# Altium schematic sheet Main Board.SchDoc
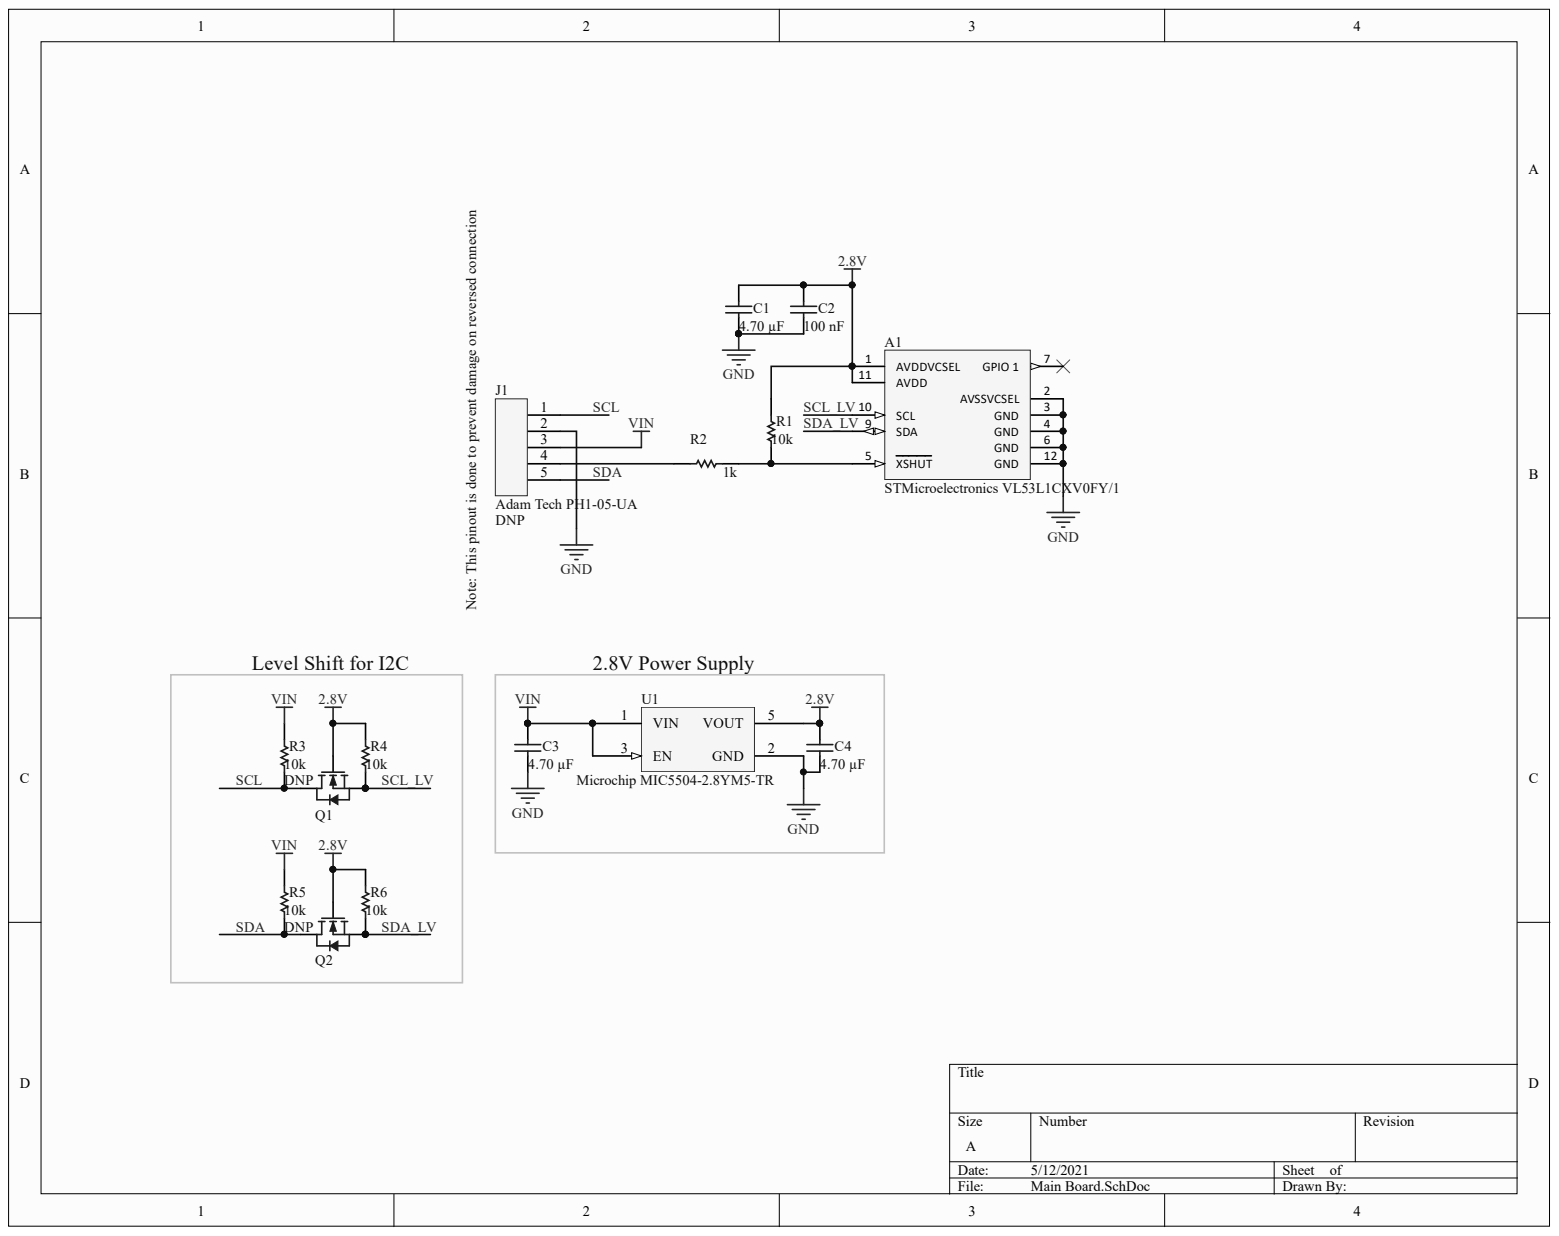
[diagram p0: Main Board.SchDoc]

## Parts
A1  STMicroelectronics VL53L1CXV0FY/1  Manufacturer Part Number: VL53L1CXV0FY/1  [VL53L1C]
C1  Capacitance: 4.70 µF  [PCB-4kws2ejwr5jtmehi4fny-1]  (hidden comment: Samsung CL10A475MQ8NNNC)
C2  Capacitance: 100 nF  [CAPC1005X56X25NL10T15]  (hidden comment: Samsung CL05A104KA5NNNC)
C3  Capacitance: 4.70 µF  [PCB-4kws2ejwr5jtmehi4fny-1]  (hidden comment: Samsung CL10A475MQ8NNNC)
C4  Capacitance: 4.70 µF  [PCB-4kws2ejwr5jtmehi4fny-1]  (hidden comment: Samsung CL10A475MQ8NNNC)
J1  Adam Tech PH1-05-UA  Manufacturer Part Number: PH1-05-UA  [PCB-wzxd1d1storh51uhdjer-1]
Q1  Nexperia 2N7002NXAKR  [PCB-lygu1wj07d1mwbs3aw73-1]  (value not drawn)
Q2  Nexperia 2N7002NXAKR  [PCB-lygu1wj07d1mwbs3aw73-1]  (value not drawn)
R1  Resistance: 10k  [RMCF0402]  (hidden comment: Stackpole Electronics RMCF0402JT10K0)
R2  Resistance: 1k  [PCB-xfncg8r2z74zylg35qj5-1]  (hidden comment: Stackpole Electronics RMCF0402JT1K00)
R3  Resistance: 10k  [RMCF0402]  (hidden comment: Stackpole Electronics RMCF0402JT10K0)
R4  Resistance: 10k  [RMCF0402]  (hidden comment: Stackpole Electronics RMCF0402JT10K0)
R5  Resistance: 10k  [RMCF0402]  (hidden comment: Stackpole Electronics RMCF0402JT10K0)
R6  Resistance: 10k  [RMCF0402]  (hidden comment: Stackpole Electronics RMCF0402JT10K0)
U1  Microchip MIC5504-2.8YM5-TR  Manufacturer Part Number: MIC5504-2.8YM5-TR  [PCB-87v9kgqs8q23ekp4z6f0-1]

## Nets
2.8V: A1.1(AVDDVCSEL) A1.11(AVDD) C1.2 C2.2 C4.2 Q1.1 Q2.1 R1.2 R4.2 R6.2 U1.5(VOUT)
GND: A1.2(AVSSVCSEL) A1.3(GND) A1.4(GND) A1.6(GND) A1.12(GND) C1.1 C2.1 C3.1 C4.1 J1.2 U1.2(GND)
VIN: C3.2 J1.3 R3.2 R5.2 U1.1(VIN) U1.3(EN)
SCL: J1.1 Q1.3 R3.1
SCL_LV: A1.10(SCL) Q1.2 R4.1
SDA: J1.5 Q2.3 R5.1
SDA_LV: A1.9(SDA) Q2.2 R6.1
NetA1_5: A1.5(XSHUT) R1.1 R2.2
NetJ1_4: J1.4 R2.1

Not connected: A1 7(GPIO_1)

## Library data of the parts
A1 = CMP-646fa835e915bba8-12: Proximity Sensor Environmental 4mm 2.6V to 3.5VDC 12-Pin LGA T/R; Lead-Free Status: Lead Free; Supply Voltage (DC): 2.60 V (min), 3.50 V (max); Component Kind: Standard; Library Name: CommunityVault; Lifecycle Status: Not Listed by Manufacturer; OctopartID: 646fa835e915bba8; Octopart URL: https://octopart.com/vl53l1cxv0fy%2F1-stmicroelectronics-89171996; Operating Temperature: -20.0 °C (min); Packaging: Cut Tape (CT); RoHS: Compliant
C1 C3 C4 = CMP-044acc6ebbc7db8d-2: CL10 Series 4.7 uF 6.3 V ±20 % Tolerance X5R SMT Multilayer Ceramic Capacitor; Capacitance Tolerance: ±20%; Lead-Free Status: Lead Free; Voltage Rating (DC): 6.30 V; Case/Package: 0603; Component Kind: Standard; Dielectric Characteristic: X5R; Library Name: CommunityVault; Manufacturer Part Number: CL10A475MQ8NNNC; OctopartID: 044acc6ebbc7db8d; Octopart URL: https://octopart.com/cl10a475mq8nnnc-samsung-19831531; RoHS: Compliant
C2 = CMP-bb3e7316aa8864f9-2: Ceramic capacitor SMD-0402 100nF /25V X5R# K +-10%, Samsung, RoHS; Capacitance Tolerance: ±10%; Dielectric Characteristic: X5R; Lead-Free Status: Lead Free; Voltage Rating (DC): 25.0 V; Case/Package: 0402; Component Kind: Standard; Library Name: CommunityVault; Manufacturer Part Number: CL05A104KA5NNNC; Mounting Style: Surface Mount; OctopartID: bb3e7316aa8864f9; Octopart URL: https://octopart.com/cl05a104ka5nnnc-samsung-19018960; Operating Temperature: -55.0 °C (min); RoHS: Compliant
J1 = CMP-cf9c06eec890105b-1: Conn Unshrouded Header HDR 5 POS 2.54mm Solder ST Thru-Hole; Lead-Free Status: Lead Free; Component Kind: Standard; DNP: DNP; Library Name: CommunityVault; OctopartID: cf9c06eec890105b; Octopart URL: https://octopart.com/ph1-05-ua-adam+tech-7873130; Packaging: Bag; RoHS: Compliant
Q1 Q2 = CMP-ee2f2100224d31e8-4: 2N7002NXAK - 60 V, single N-channel Trench MOSFET; Component Kind: Standard; Library Name: CommunityVault; Manufacturer Part Number: 2N7002NXAKR; OctopartID: ee2f2100224d31e8; Octopart URL: https://octopart.com/2n7002nxakr-nexperia-103147780
R1 R3-R6 = CMP-bdbc7593b15d627b-1: Res Thick Film 0402 10K Ohm 5% 0.063W(1/16W) ±100ppm/C Pad SMD Automotive T/R; Lead-Free Status: Lead Free; Power Rating: 63.0 mW; Case/Package: 0402; Component Kind: Standard; Library Name: CommunityVault; Manufacturer Part Number: RMCF0402JT10K0; Mounting Style: Surface Mount; OctopartID: bdbc7593b15d627b; Octopart URL: https://octopart.com/rmcf0402jt10k0-stackpole+electronics-19212679; Packaging: Tape & Reel (TR); Resistance Tolerance: ±5%; RoHS: Compliant
  R3 R5: DNP: DNP
R2 = CMP-aa9b62b4a66a755c-1: Res Thick Film 0402 1K Ohm 5% 0.063W(1/16W) ±100ppm/C Pad SMD Automotive T/R; Lead-Free Status: Lead Free; Power Rating: 63.0 mW; Case/Package: 0402; Component Kind: Standard; Library Name: CommunityVault; Manufacturer Part Number: RMCF0402JT1K00; Mounting Style: Surface Mount; OctopartID: aa9b62b4a66a755c; Octopart URL: https://octopart.com/rmcf0402jt1k00-stackpole+electronics-19212695; Packaging: Tape & Reel (TR); Resistance Tolerance: ±5%; RoHS: Compliant
U1 = CMP-260decfc55bff9ca-2: Single 300mA LDO with Enable Pull-Down & Auto Discharge 5 SOT-23 T/R RoHS Compliant: Yes; Case/Package: SOT-23; Component Kind: Standard; Library Name: FavoritesLibrary; Lifecycle Status: Not Listed by Manufacturer; Mounting Style: Surface Mount; Number of Pins: 5; OctopartID: 260decfc55bff9ca; Octopart URL: https://octopart.com/mic5504-2.8ym5-tr-microchip-66803412; Operating Temperature: -40.0 °C (min); Output Current: 300 mA; Packaging: Cut Tape (CT), Tape & Reel (TR); REACH SVHC Compliance: No SVHC; RoHS: Compliant
